# Altium schematic sheet RS232_XBEE_MB_I2C_ETH.SchDoc
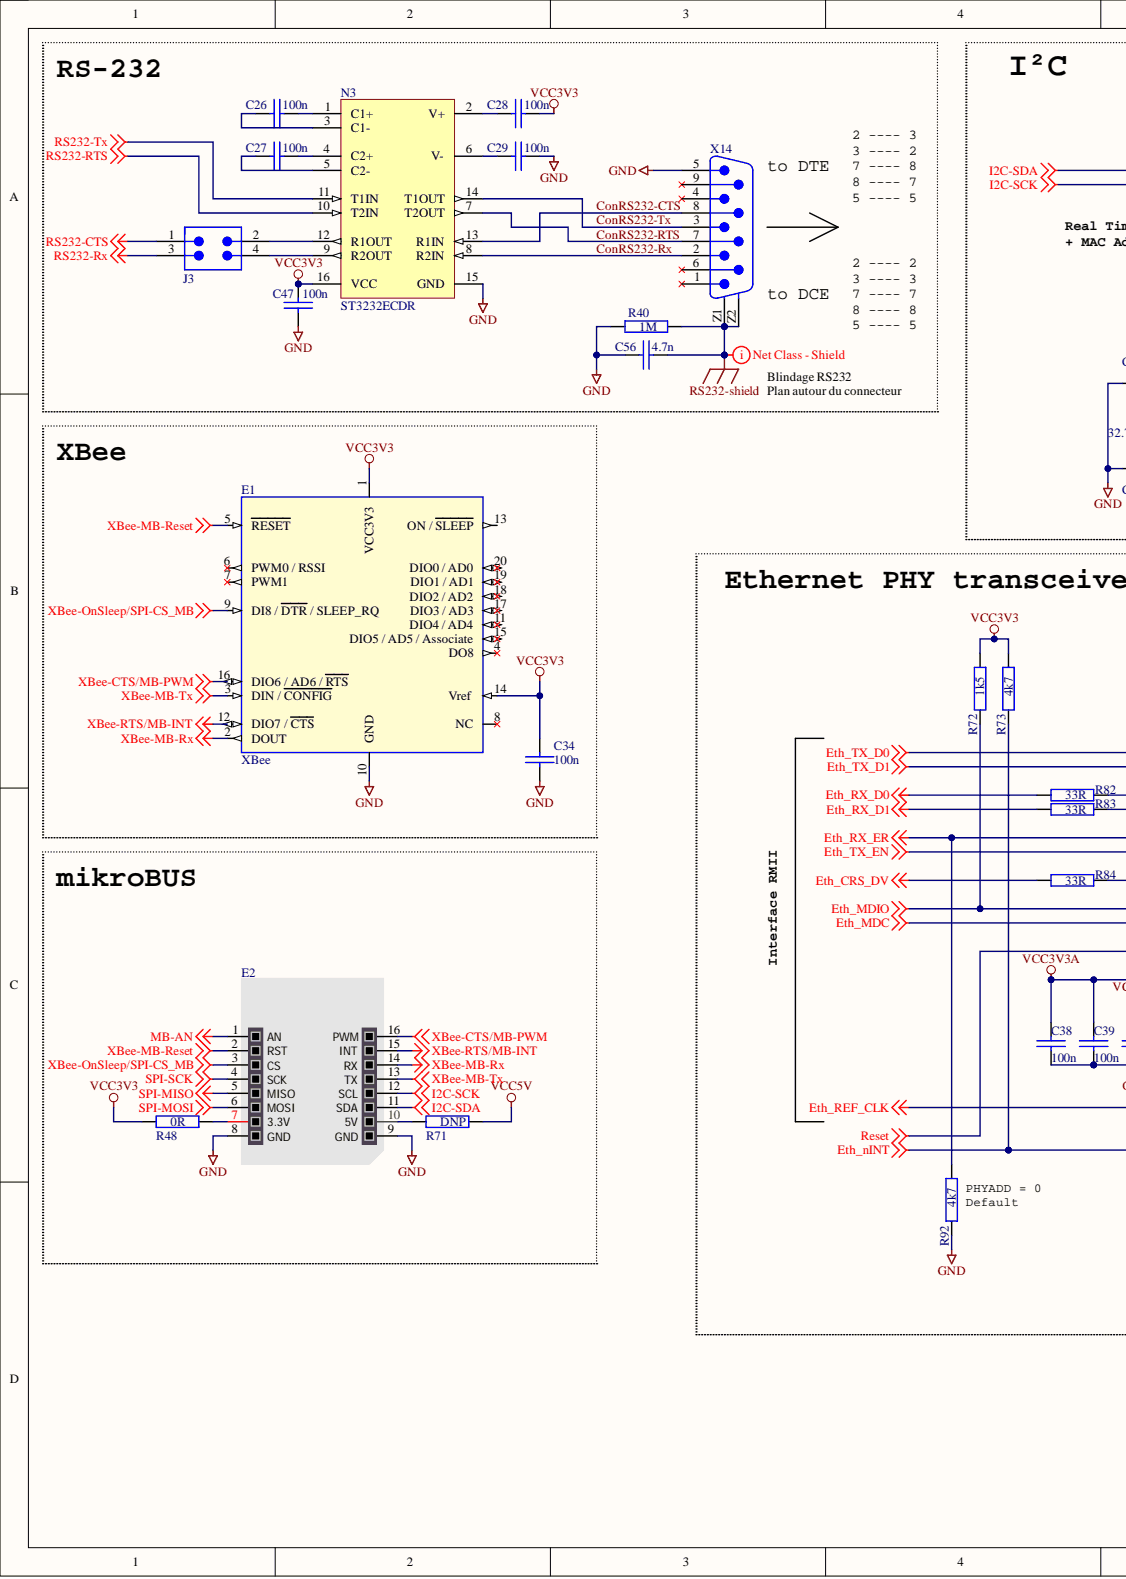
[diagram p0: RS232_XBEE_MB_I2C_ETH.SchDoc, left]
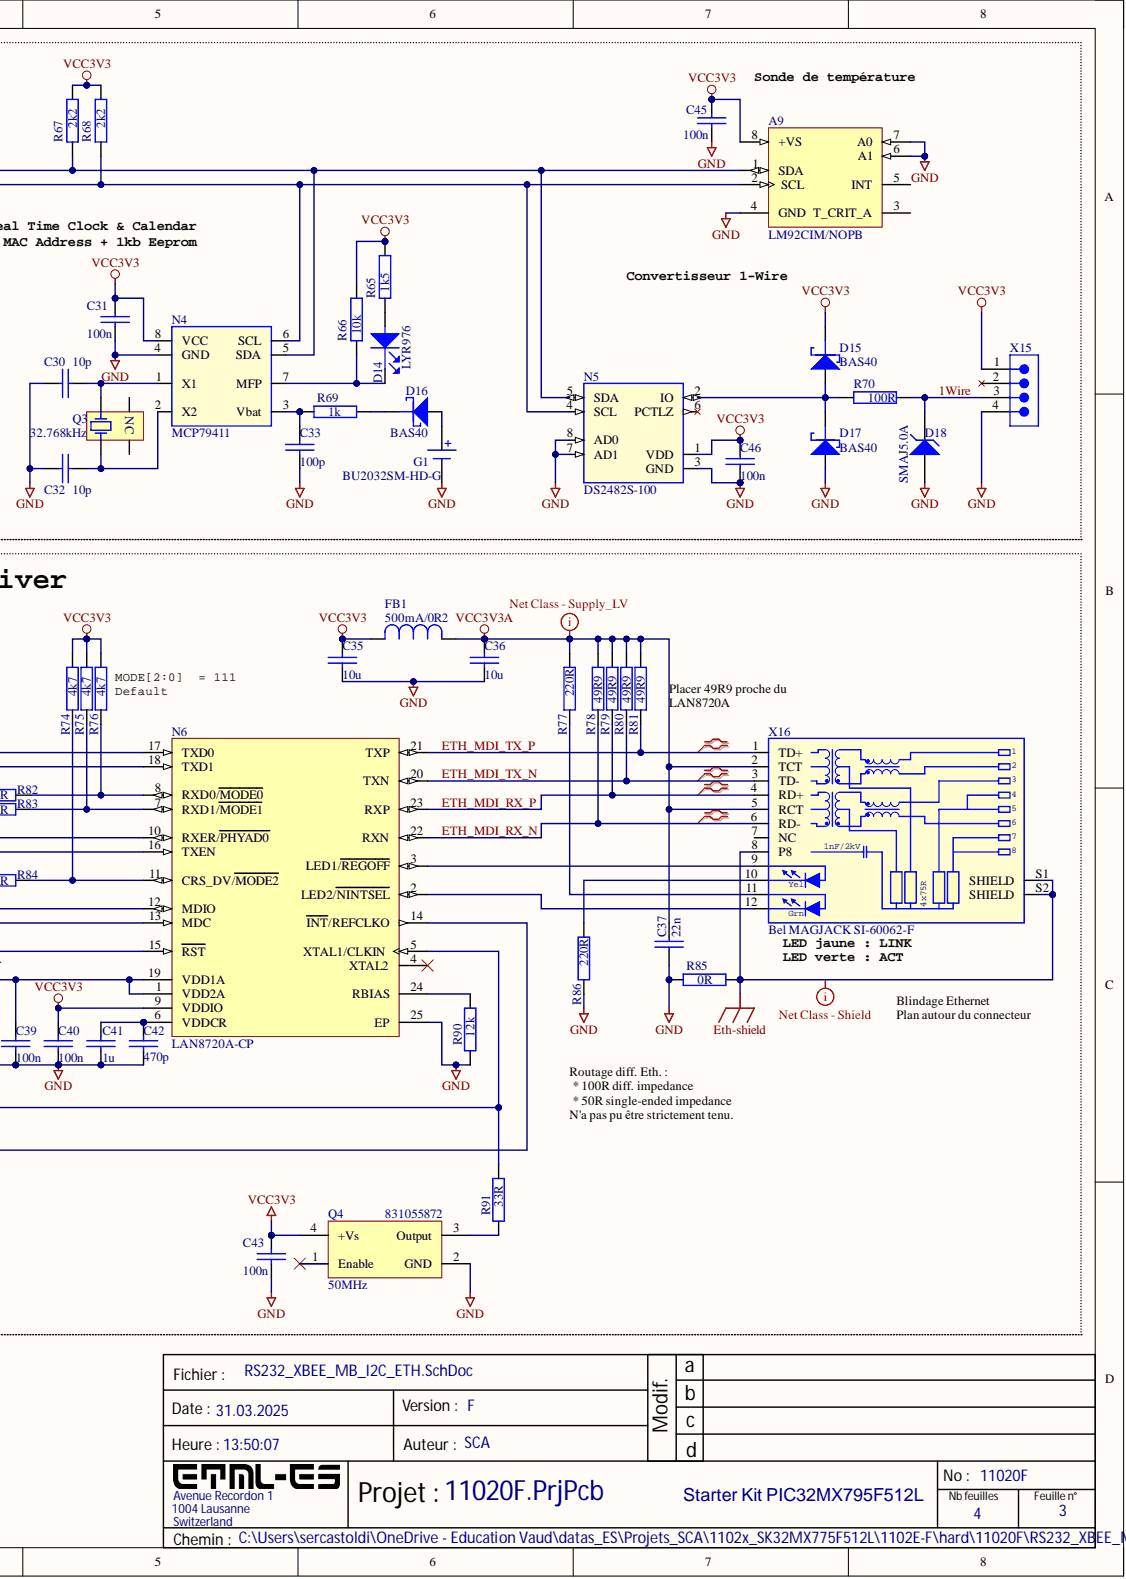
[diagram p1: RS232_XBEE_MB_I2C_ETH.SchDoc, right]

## Parts
A9  LM92CIM/NOPB  [FP-S8-4-IPC_A]
C26  100n  [CAPC0805(2012)145_N]
C27  100n  [CAPC0805(2012)145_N]
C28  100n  [CAPC0805(2012)145_N]
C29  100n  [CAPC0805(2012)145_N]
C30  10p  [CAPC0805(2012)145_N]
C31  100n  [CAPC0805(2012)145_N]
C32  10p  [CAPC0805(2012)145_N]
C33  100p  [CAPC0805(2012)145_N]
C34  100n  [CAPC0805(2012)145_N]
C35  10u  [CAPC0805(2012)145_N]
C36  10u  [CAPC0805(2012)145_N]
C37  22n  [CAPC0805(2012)145_N]
C38  100n  [CAPC0805(2012)145_N]
C39  100n  [CAPC0805(2012)145_N]
C40  100n  [CAPC0805(2012)145_N]
C41  1u  [CAPC0805(2012)145_N]
C42  470p  [CAPC0805(2012)145_N]
C43  100n  [CAPC0805(2012)145_N]
C45  100n  [CAPC0805(2012)145_N]
C46  100n  [CAPC0805(2012)145_N]
C47  100n  [CAPC0805(2012)145_N]
C56  4.7n  [CAPC0805(2012)145_N]
D14  LYR976  [LED_0805]
D15  BAS40  [FP-SOT23-IPC_A]
D16  BAS40  [FP-SOT23-IPC_A]
D17  BAS40  [FP-SOT23-IPC_A]
D18  SMAJ5.0A  [WE-TVSP_DO-214AC_Unidirectional]
E1  XBee
E2  MIKROBUS HOST CONN.  [MIKROBUS HOST CONN. TH]  (value not drawn)
FB1  500mA/0R2  [IND_0805]
G1  BU2032SM-HD-G  [BU2032SM-HD-G]
J3  61300421121  [61300421121]  (value not drawn)
N3  ST3232ECDR  [SOIC-16]
N4  MCP79411  [SOIC-SN8_N]
N5  DS2482S-100  [FP-S8-4-IPC_A]
N6  LAN8720A-CP  [MCHP-QFN-24_V]
Q3  Frequency: 32.768kHz  [EPSON-MC-306_V]  (hidden comment: MC-306 32.7680K-A3: ROHS)
Q4  831055872  Frequency: 50MHz  [WE-SPXO_CFPS-39_customES]
R40  1M  [RESC0805(2012)_N]
R48  0R  [RESC0805(2012)_N]
R65  1k5  [RESC0805(2012)_N]
R66  10k  [RESC0805(2012)_N]
R67  2k2  [RESC0805(2012)_N]
R68  2k2  [RESC0805(2012)_N]
R69  1k  [RESC0805(2012)_N]
R70  100R  [RESC0805(2012)_N]
R71  DNP  [RESC0805(2012)_N]
R72  1k5  [RESC0805(2012)_N]
R73  4k7  [RESC0805(2012)_N]
R74  4k7  [RESC0805(2012)_N]
R75  4k7  [RESC0805(2012)_N]
R76  4k7  [RESC0805(2012)_N]
R77  220R  [RESC0805(2012)_N]
R78  49R9  [RESC0805(2012)_N]
R79  49R9  [RESC0805(2012)_N]
R80  49R9  [RESC0805(2012)_N]
R81  49R9  [RESC0805(2012)_N]
R82  33R  [RESC0805(2012)_N]
R83  33R  [RESC0805(2012)_N]
R84  33R  [RESC0805(2012)_N]
R85  0R  [RESC0805(2012)_N]
R86  220R  [RESC0805(2012)_N]
R90  12k  [RESC0805(2012)_N]
R91  33R  [RESC0805(2012)_N]
R92  4k7  [RESC0805(2012)_N]
X14  618009211721  [618009211721]  (value not drawn)
X15  61300411121  [61300411121]  (value not drawn)
X16  Bel MAGJACK SI-60062-F  [BEL_SI-60062-F]

## Nets
Eth-shield: R85.2 X16.8(P8) X16.S1(SHIELD) X16.S2(SHIELD)
Eth_CRS_DV: R84.1
Eth_MDC: N6.13(MDC)
Eth_MDIO: N6.12(MDIO) R72.2
Eth_nINT: N6.14(INT/REFCLKO) R73.2
Eth_REF_CLK: N6.5(XTAL1/CLKIN) R91.2
Eth_RX_D0: R82.1
Eth_RX_D1: R83.1
Eth_RX_ER: N6.10(RXER/PHYAD0) R92.1
Eth_TX_D0: N6.17(TXD0)
Eth_TX_D1: N6.18(TXD1)
Eth_TX_EN: N6.16(TXEN)
GND: A9.4(GND) A9.6(A1) A9.7(A0) C29.2 C30.1 C31.1 C32.1 C33.1 C34.1 C35.1 C36.1 C37.2 C38.2 C39.2 C40.2 C41.1 C42.1 C43.1 C45.2 C46.2 C47.2 C56.1 D17.1 D18.2 E1.10(GND) E2.8(GND) E2.9(GND) G1.2 N3.15(GND) N4.4(GND) N5.3(GND) N5.7(AD1) N5.8(AD0) N6.25(EP) Q4.2(GND) R40.1 R85.1 R86.2 R90.1 R92.2 X14.5 X15.4
I2C-SCK: A9.2(SCL) E2.12(SCL) N4.6(SCL) N5.4(SCL) R68.2
I2C-SDA: A9.1(SDA) E2.11(SDA) N4.5(SDA) N5.5(SDA) R67.2
MB-AN: E2.1(AN)
Reset: N6.15(RST)
RS232-CTS: J3.1
RS232-RTS: N3.10(T2IN)
RS232-Rx: J3.3
RS232-shield: C56.2 R40.2 X14.Z1 X14.Z2
RS232-Tx: N3.11(T1IN)
SPI-MISO: E2.5(MISO)
SPI-MOSI: E2.6(MOSI)
SPI-SCK: E2.4(SCK)
VCC3V3: A9.8(+VS) C28.2 C31.2 C34.2 C35.2 C40.1 C43.2 C45.1 C46.1 C47.1 D15.3 E1.1(VCC3V3) E1.14(Vref) FB1.1 N3.16(VCC) N4.8(VCC) N5.1(VDD) N6.9(VDDIO) Q4.4(+Vs) R48.1 R65.1 R66.1 R67.1 R68.1 R72.1 R73.1 R74.1 R75.1 R76.1 X15.1
VCC3V3A: C36.2 C37.1 C38.1 C39.1 FB1.2 N6.1(VDD2A) N6.19(VDD1A) R77.1 R78.1 R79.1 R80.1 R81.1 X16.2(TCT) X16.5(RCT)
VCC5V: R71.2
XBee-CTS/MB-PWM: E1.16(DIO6_/_AD6_/_RTS) E2.16(PWM)
XBee-MB-Reset: E1.5(RESET) E2.2(RST)
XBee-MB-Rx: E1.2(DOUT) E2.14(RX)
XBee-MB-Tx: E1.3(DIN_/_CONFIG) E2.13(TX)
XBee-OnSleep/SPI-CS_MB: E1.9(DI8_/_DTR_/_SLEEP_RQ) E2.3(CS)
XBee-RTS/MB-INT: E1.12(DIO7_/_CTS) E2.15(INT)
1Wire: D18.1 R70.2 X15.3
ConRS232-CTS: N3.13(R1IN) X14.8
ConRS232-RTS: N3.7(T2OUT) X14.7
ConRS232-Rx: N3.8(R2IN) X14.2
ConRS232-Tx: N3.14(T1OUT) X14.3
ETH_MDI_RX_N: N6.22(RXN) R78.2 X16.6(RD-)
ETH_MDI_RX_P: N6.23(RXP) R79.2 X16.4(RD+)
ETH_MDI_TX_N: N6.20(TXN) R80.2 X16.3(TD-)
ETH_MDI_TX_P: N6.21(TXP) R81.2 X16.1(TD+)
NetC26_1: C26.1 N3.3(C1-)
NetC26_2: C26.2 N3.1(C1+)
NetC27_1: C27.1 N3.5(C2-)
NetC27_2: C27.2 N3.4(C2+)
NetC28_1: C28.1 N3.2(V+)
NetC29_1: C29.1 N3.6(V-)
NetC30_2: C30.2 N4.1(X1) Q3.1
NetC32_2: C32.2 N4.2(X2) Q3.4
NetC33_2: C33.2 N4.3(Vbat) R69.1
NetC41_2: C41.2 C42.2 N6.6(VDDCR)
NetD14_1: D14.1 N4.7(MFP) R66.2
NetD14_2: D14.2 R65.2
NetD15_1: D15.1 D17.3 N5.2(IO) R70.1
NetD16_1: D16.1 G1.1
NetD16_3: D16.3 R69.2
NetE2_7: E2.7(3.3V) R48.2
NetE2_10: E2.10(5V) R71.1
NetJ3_2: J3.2 N3.12(R1OUT)
NetJ3_4: J3.4 N3.9(R2OUT)
NetN6_2: N6.2(LED2/NINTSEL) X16.12
NetN6_3: N6.3(LED1/REGOFF) X16.9
NetN6_7: N6.7(RXD1/MODE1) R75.2 R83.2
NetN6_8: N6.8(RXD0/MODE0) R76.2 R82.2
NetN6_11: N6.11(CRS_DV/MODE2) R74.2 R84.2
NetN6_24: N6.24(RBIAS) R90.2
NetQ4_3: Q4.3(Output) R91.1
NetR77_2: R77.2 X16.11
NetR86_1: R86.1 X16.10

Not connected: A9 3(T_CRIT_A) 5(INT); E1 4(DO8) 6(PWM0_/_RSSI) 7(PWM1) 8(NC) 11(DIO4_/_AD4) 13(ON_/_SLEEP) 15(DIO5_/_AD5_/_Associate) 17(DIO3_/_AD3) 18(DIO2_/_AD2) 19(DIO1_/_AD1) 20(DIO0_/_AD0); N5 6(PCTLZ); N6 4(XTAL2); Q3 2(NC) 3; Q4 1(Enable); X14 1 4 6 9; X15 2; X16 7(NC)

## Library data of the parts
A9 = CMP-0066-00060-3: ±0.33°C Accurate, 12-Bit + Sign Temperature Sensor and Thermal Window Comparator with Two-Wire Interface, 8-pin Narrow SOIC, Pb-Free; Manufacturer: Texas Instruments; CompType: Temp Sensor IC; PackageTopMarking: NSZXTT*LM92*CIM; PowerWise: Y; Code_IPC: SOIC127P600-8; Code_JEDEC: MS-012-AA; Component Kind: Standard; ComponentLink1Description: Datasheet URL; ComponentLink1URL: http://www.ti.com/general/docs/lit/getliterature.tsp?genericPartNumber=LM92&fileType=pdf; Library Name: Altium Content Vault; PackageDescription: SOIC Narrow, 4.9 x 3.9 x 1.45mm, 8 Lead, 1.27mm Pitch; PackageReference: D0008A; PinCount: 8; RoHS: Y
C26-C43 C45-C47 C56 = C: Component Kind: Standard
  C26-C29 C31 C34 C38-C40 C43 C45-C47: Value: 100n
  C30 C32: Value: 10p
  C33: Value: 100p
  C35 C36: Value: 10u
  C37: Value: 22n
  C41: Value: 1u
  C42: Value: 470p
  C56: Value: 4.7n
D14 = LED_0: LED YELLOW DIFFUSED 0805 SMD; Lens Color: White; Lens Style: Flat; Case Code (Imperial): 0805; Case Code (Metric): 2012; Case/Package: 0805; Color: Yellow; Component Kind: Standard; Illumination Color: Yellow; Library Name: 11020E.SCHLIB; Mount: Surface Mount
D15-D17 = BAS40_0: Schottky Barrier Diode, 3-Pin SOT-23, Pb-Free, Tape and Reel; PartNumber: BAS40LT1G; Component Kind: Standard
D18 = 824500500: WE-TVSP SMT Power TVS Diode, DO-214AC, Unidirectional, 400W, 5VDC; Manufacturer: Wurth Elektronik; (Reverse) Breakdown Voltage: 6.7V; (Reverse) Peak Pulse Current: 43.5A; Clamping Voltage: 9.2V; Operating Voltage (DC): 5V; Case/Size Code: DO-214AC; Category: Surge Protection; Component Kind: Standard; ComponentLink1Description: Website Link; ComponentLink1URL: https://www.we-online.com/redexpert/article/824500500?ad; ComponentLink2Description: Datasheet Link; ComponentLink2URL: https://www.we-online.com/redexpert/spec/824500500?ad; Height: 2.25mm; Length: 4.24mm; Library Name: Altium_WE-TVSP (rev22b).IntLib; Manufacturer Part Number: 824500500; Match Code: WE-TVSP; Mount: Surface Mount; Operating Temperature Max: 150°C; Operating Temperature Min: -65°C; Packaging: Tape and Reel; Polarity: Unidirectional; Power Dissipation: 400W; Width: 2.67mm
E2 = MIKROBUS HOST CONN._1: mikroBUS Host Socket; Component Kind: Standard; Library Name: mikroBUS_socket_standard.SchLib; SMD: No
FB1 = IND_0805_Ferrite Bead: FERRITE CHIP 600 OHM 500MA 0805; Manufacturer: TDK Corporation; Manufacturer Part Number: MMZ2012Y601B; DC Resistance (DCR): 200 mOhm Max; Filter Type: Differential Mode - Single; Impedance @ Frequency: 600 Ohm @ 100MHz; Category: Filters; Component Kind: Standard; ComponentLink1Description: http://www.tdk.co.jp/tefe02/e9412_mmz.pdf; ComponentLink1URL: http://www.tdk.co.jp/tefe02/e9412_mmz.pdf; Current Rating: 500mA; Height (Max): 0.041" (1.05mm); Mounting Type: Surface Mount; Package / Case: 0805 (2012 Metric); Packaging: Cut Tape (CT); Pricing 1: 1=0.11, 10=0.088, 100=0.0502, 250=0.04224, 500=0.03344, 1000=0.02816 (USD); RoHS: RoHS Compliant; Size / Dimension: 0.079" L x 0.049" W (2.00mm x 1.25mm); Stock 1: 172007; Supplier: Digi-Key; Supplier Part Number 1: 445-1558-1-ND; Library Name: HPMD Lib.SchLib
G1 = BU2032SM-HD-G_1: CR2032 Battery holder; Component Kind: Standard; LatestRevisionDate: 17-Jul-2002; LatestRevisionNote: Re-released for DXP Platform.; Library Name: 11020E.SCHLIB; PackageDescription: Battery; 2 Leads; PackageReference: BAT-2; Published: 24-Mar-1999; Supplier: Digikey,Stock ES; Supplier min. qty: 1; Supplier Ref.: BU2032SM-HD-GCT-ND; Supplier unit price: 0.87; Value: BU2032SM-HD-G; Publisher: Altium Limited
J3 = 61300421121: WR-PHD Pin Header, THT, Vertical, pitch 2.54mm, 2 Row, 4P; Manufacturer: Wurth Elektronik; Contact Resistance: 20mOhm; Rated Current: 3A; Withstanding Voltage: 500V; Working Voltage: 250V; Category: Board-to-Board Connectors; Component Kind: Standard; ComponentLink1Description: Website Link; ComponentLink1URL: https://www.we-online.com/redexpert/article/61300421121?ad; ComponentLink2Description: Datasheet Link; ComponentLink2URL: https://www.we-online.com/redexpert/spec/61300421121?ad; Gender: Pin Header; Library Name: Altium_WR-PHD (rev24a).IntLib; Manufacturer Part Number: 61300421121; Match Code: WR-PHD; Mount: Through Hole; Number of Pins: 4; Number of Rows: 2; Operating Temperature Max: 105°C; Operating Temperature Min: -40°C; Orientation: Vertical; Packaging: Bag; Pitch: 2.54mm
N3 = CMP-2000-07156-1: Low Power, Up to 400 kbps RS-232 Driver and Receiver, 3 to 5.5 V, 0 to 70 degC, 16-Pin SOIC, RoHS, Tape and Reel; Manufacturer: STMicroelectronics; Data Transmission Topology: Point-to-Point; Dual Supply Voltage (Max): NotRequiredV; Interface Circuit Standard 1: EIA/TIA-232/RS-232/V.24/V.28; Number of Receivers: 2; Number of Transceivers: 1; Power Supply Requirement: Single; Receiver Signal Type: Single-Ended; Single Supply Voltage (Max): 5.5V; Single Supply Voltage (Min): 3V; Single Supply Voltage (Typ): 3.3/5V; Supply Current: 2mA; Component Kind: Standard; Data Rate: 400Kbps; Datasheet URL: https://datasheet.ciiva.com/41459/001185215-da-01-en-ic-txrx-3-5-5v-rs2-st3232cdr-soic-16-stm-41459767.pdf; Datasheet Version: Rev. 11, 02/2008; Dual Supply Voltage (Min): NotRequiredV; Dual Supply Voltage (Typ): NotRequiredV; Manufacturer URL: http://www.st.com/; Mounting: SurfaceMount; Mounting Technology: SurfaceMount; Number of Transmitters: 2; Operating Temp Range: 0Cto70C; Operating Temperature Classification: Commercial; Package Description: 16-Pin Small Outline Integrated Circuit, Body 9.9 x 3.9 mm, Pitch 1.27 mm; Package Reference: SO-16; Package Type: SO; Packaging: TapeandReel; Pin Count: 16; Supplier: Digikey; Supplier Ref.: 497-6537-1-ND; Supplier unit price: 1.04; Transmitter Signal Type: Single-Ended
N5 = DS2482-100: Component Kind: Standard; Library Name: 11020E.SCHLIB
N6 = CMP-2000-05216-1: RMII 10/100 Ethernet Transceiver with HP Auto-MDIX Support, -40 to 85 degC, 24-Pin QFN, RoHS, Tray; Manufacturer: Microchip; Dynamic Catalog: PhysicalLayerTransceiver; Number of Drivers/Receivers: 4/4; Other Names: 638-1084; Voltage - Supply: 1.6V~3.6V; Category: IntegratedCircuits(ICs); Component Kind: Standard; Datasheet URL: http://ww1.microchip.com/downloads/en/DeviceDoc/8720a.pdf; Datasheet Version: Rev. 1.4, 08/2012; Family: Interface-Drivers,Receivers,Transceivers; Library Name: Altium Content Vault; Manufacturer URL: http://www.microchip.com/; Mounting Type: SurfaceMount; Package / Case: 24-VFQFNExposedPad; Package Description: 24-Pin Quad Flat No-lead Package, Body 4 x 4 mm, Pitch 0.5 mm; Package Reference: QFN-24; Packaging: Tray; Protocol: RMII; Series: -; Standard Package: 490; Supplier Device Package: 24-QFN(4x4); Type: Transceiver
Q3 = MC-306 32.7680K-A0: Crystal, 32.768 kHz, 12.5 pF, 50 KOhm, -40 to 85 degC, 4-Pin SMD, RoHS, Tape and Reel; Manufacturer: Epson; Catalog Drawings: MC-306SeriesSide  MC-306SeriesTop  MC-306SeriesCircuit; Dynamic Catalog: MC-306,MC-405andMC-406Series; ESR (Equivalent Series Resistance): 50kOhm; Frequency Tolerance: ±20ppm; Load Capacitance: 12.5pF; Operating Mode: Fundamental; Product Training Modules: Crystals; Type: kHzCrystal(TuningFork); Category: CrystalsandOscillators; Component Kind: Standard; Datasheet URL: https://support.epson.biz/td/api/doc_check.php?dl=brief_MC-306_en.pdf; Datasheet Version: 01/2014; Family: Crystals; Library Name: 11020E.SCHLIB; Manufacturer URL: https://www.eea.epson.com/; Mounting Technology: SurfaceMount; Mounting Type: SurfaceMount; Operating Temperature: -40°C~85°C; Package / Case: 4-SOJ,5.50mmpitch; Package Description: 4-Pin Surface Mount Device, Body 8 x 3.2 mm; Package Reference: MC-306; Packaging: Tape&Reel(TR); Series: MC-306; Standard Package: 1,000; Supplier: Digikey; Supplier min. qty: 1; Supplier Ref.: SER4306CT-ND; Supplier unit price: 1.61; Value: MC-306 32.7680K-A3: ROHS
Q4 = 831055872: WE-SPXO Simple Packaged Quartz Oscillator, SMT, CFPS-39, 50MHz, 3.3V; Manufacturer: Wurth Elektronik; Frequency Stability: +/-25ppm; Case/Size Code: CFPS-39; Category: Oscillators; Component Kind: Standard; ComponentLink1Description: Website Link; ComponentLink1URL: https://www.we-online.com/redexpert/article/831055872?ad; ComponentLink2Description: Datasheet Link; ComponentLink2URL: https://www.we-online.com/redexpert/spec/831055872?ad; Height: 1.2mm; Length: 3.2mm; Library Name: WE-SPXO.SchLib; Manufacturer Part Number: 831055872; Match Code: WE-SPXO; Mount: Surface Mount; Operating Temperature Max: 85°C; Operating Temperature Min: -40°C; Packaging: Tape and Reel; Supply Voltage: 3.3V; Width: 2.5mm
R40 R48 R65-R86 R90-R92 = R: Component Kind: Standard
  R40: Value: 1M
  R48 R85: Value: 0R
  R65 R72: Value: 1k5
  R66: Value: 10k
  R67 R68: Value: 2k2
  R69: Value: 1k
  R70: Value: 100R
  R71: Value: DNP
  R73-R76 R92: Value: 4k7
  R77 R86: Value: 220R
  R78-R81: Value: 49R9
  R82-R84 R91: Value: 33R
  R90: Value: 12k
X14 = 618009211721: WR-DSUB Male Angled PCB Connector; Manufacturer: W¨¹rth Elektronik eiSos GmbH; ComponentLink1 Description: Manufacturer; ComponentLink2 Description: Datasheet; NoPins: 9; Component Kind: Standard; ComponentLink1URL: http://www.we-online.de; ComponentLink2URL: http://katalog.we-online.de/em/datasheet/6180xx211721.pdf; Created Date: 10/16/2014; Library Name: 11020E.SCHLIB; partNo: 618009211721; Supplier: Digikey; Supplier Ref.: AE10968-ND; Supplier unit price: 0.77; Created by: Karrer Zheng
X15 = 61300411121: WR-PHD Pin Header, THT, Vertical, pitch 2.54mm, 1 Row, 4P; Manufacturer: Wurth Elektronik; Contact Resistance: 20mΩ; Rated Current: 3A; Withstanding Voltage: 500V; Working Voltage: 250V; Category: Board-to-Board Connectors; Component Kind: Standard; ComponentLink1Description: Website Link; ComponentLink1URL: https://www.we-online.com/redexpert/article/61300411121?ad; ComponentLink2Description: Datasheet Link; ComponentLink2URL: https://www.we-online.com/redexpert/spec/61300411121?ad; Gender: Pin Header; Library Name: Altium_WR-PHD (rev22b).IntLib; Manufacturer Part Number: 61300411121; Match Code: WR-PHD; Mount: Through Hole; Number of Pins: 4; Number of Rows: 1; Operating Temperature Max: 105°C; Operating Temperature Min: -40°C; Orientation: Vertical; Packaging: Bag; Pitch: 2.54mm
X16 = BEL_SI-60062-F: Component Kind: Standard; Library Name: 11020E.SCHLIB
E1 = XBee: Component Kind: Standard; Library Name: 11020E.SCHLIB
N4 = MCP79411: Component Kind: Standard
